# Altium schematic sheet PowerSupply.SchDoc(PowerSupply)
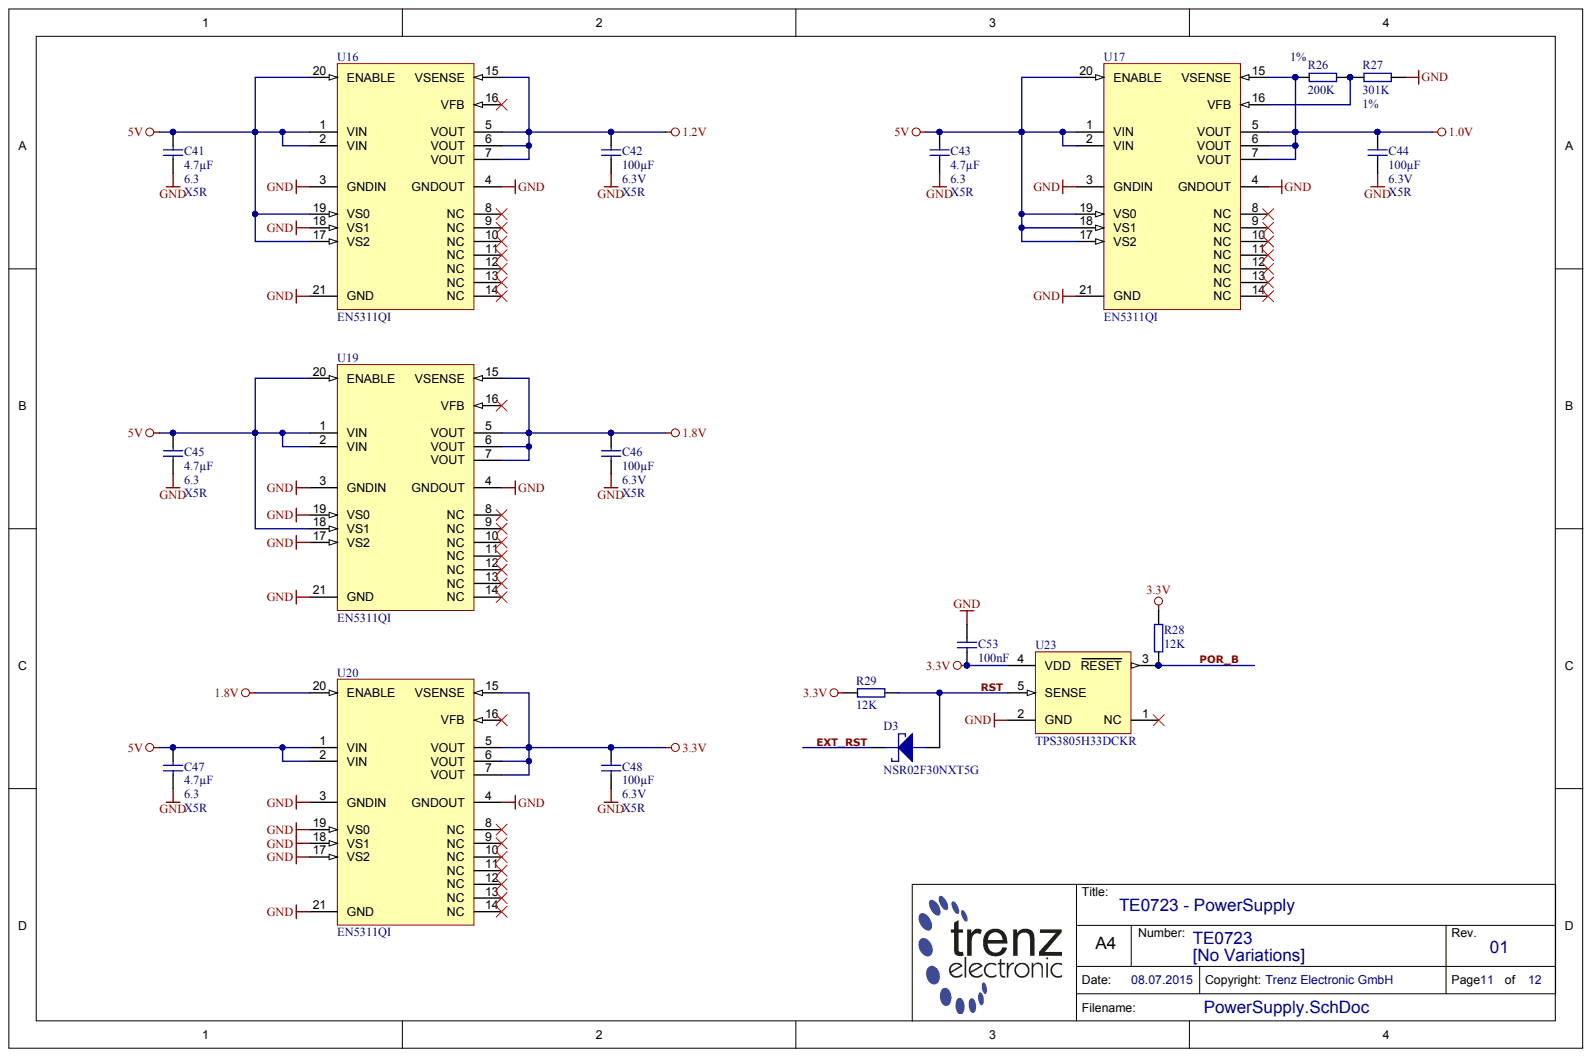
[diagram p0: PowerSupply.SchDoc]

## Parts
C41  4.7µF  Technology: X5R  Voltage: 6.3  [CAPC1005X60N]
C42  100µF  Technology: X5R  Voltage: 6.3V  [CAPC3216X180N]
C43  4.7µF  Technology: X5R  Voltage: 6.3  [CAPC1005X60N]
C44  100µF  Technology: X5R  Voltage: 6.3V  [CAPC3216X180N]
C45  4.7µF  Technology: X5R  Voltage: 6.3  [CAPC1005X60N]
C46  100µF  Technology: X5R  Voltage: 6.3V  [CAPC3216X180N]
C47  4.7µF  Technology: X5R  Voltage: 6.3  [CAPC1005X60N]
C48  100µF  Technology: X5R  Voltage: 6.3V  [CAPC3216X180N]
C53  100nF  [CAPC1005X55N]
D3  NSR02F30NXT5G  [DIOC0603X30N]
R26  200K  Tolerance: 1%  [RESC1005X40N]
R27  301K  Tolerance: 1%  [RESC1005X40N]
R28  12K  [RESC1005X40N]
R29  12K  [RESC1005X40N]
U16  EN5311QI  [EN5311QI]
U17  EN5311QI  [EN5311QI]
U19  EN5311QI  [EN5311QI]
U20  EN5311QI  [EN5311QI]
U23  TPS3805H33DCKR  [SOT65P210X110-5N]

## Nets
1.0V: C44.1 R26.1 U17.5(VOUT) U17.6(VOUT) U17.7(VOUT) U17.15(VSENSE)
1.2V: C42.1 U16.5(VOUT) U16.6(VOUT) U16.7(VOUT) U16.15(VSENSE)
1.8V: C46.1 U19.5(VOUT) U19.6(VOUT) U19.7(VOUT) U19.15(VSENSE) U20.20(ENABLE)
3.3V: C48.1 C53.2 R28.2 R29.2 U20.5(VOUT) U20.6(VOUT) U20.7(VOUT) U20.15(VSENSE) U23.4(VDD)
5V: C41.1 C43.1 C45.1 C47.1 U16.1(VIN) U16.2(VIN) U16.17(VS2) U16.19(VS0) U16.20(ENABLE) U17.1(VIN) U17.2(VIN) U17.17(VS2) U17.18(VS1) U17.19(VS0) U17.20(ENABLE) U19.1(VIN) U19.2(VIN) U19.18(VS1) U19.20(ENABLE) U20.1(VIN) U20.2(VIN)
GND: C41.2 C42.2 C43.2 C44.2 C45.2 C46.2 C47.2 C48.2 C53.1 R27.2 U16.3(GNDIN) U16.4(GNDOUT) U16.18(VS1) U16.21(GND) U17.3(GNDIN) U17.4(GNDOUT) U17.21(GND) U19.3(GNDIN) U19.4(GNDOUT) U19.17(VS2) U19.19(VS0) U19.21(GND) U20.3(GNDIN) U20.4(GNDOUT) U20.17(VS2) U20.18(VS1) U20.19(VS0) U20.21(GND) U23.2(GND)
EXT_RST: D3.1
POR_B: R28.1 U23.3(RESET)
RST: D3.2 R29.1 U23.5(SENSE)
NetR26_2: R26.2 R27.1 U17.16(VFB)

Not connected: U16 8(NC) 9(NC) 10(NC) 11(NC) 12(NC) 13(NC) 14(NC) 16(VFB); U17 8(NC) 9(NC) 10(NC) 11(NC) 12(NC) 13(NC) 14(NC); U19 8(NC) 9(NC) 10(NC) 11(NC) 12(NC) 13(NC) 14(NC) 16(VFB); U20 8(NC) 9(NC) 10(NC) 11(NC) 12(NC) 13(NC) 14(NC) 16(VFB); U23 1(NC)

## Library data of the parts
C41 C43 C45 C47 = 24719: KON 4.7µF 6.3V X5R SMD 20%; Database Table Name: C_1005_0402; Mixed: SMT; Preferred: Yes; Value: 4.7u; AN: 24719; Case: 1005 (0402); Component Kind: Standard; Library Name: TE.DbLib; Tolerance: 20%
C42 C44 C46 C48 = 23116: KON 100µF 6,3V X5R SMD 20%; Database Table Name: C_3216_1206; Mixed: SMT; Preferred: Yes; Value: 100u; AN: 23116; Case: 3216 (1206); Component Kind: Standard; Library Name: TE.DbLib; Tolerance: 20%
C53 = 26183: KON 100nF 50V SMD AEC-Q200; Database Table Name: A_C_1005_0402; Mixed: SMT; Tolerance: 10%; Value: 100n; AN: 26183; Case: 1005 (0402); Component Kind: Standard; Library Name: TE.DbLib; Technology: X7R; Voltage: 50V
D3 = 26175: Database Table Name: DIODE; Mixed: SMT; Trenz-Stock: Yes; AN: 26175; Case: DSN2 - 0201; Component Kind: Standard; Description: NSR02F30NXT5G; Library Name: TE.DbLib; HelpURL: B:\ad\adlib\datasheet\NSR02F30NXT5G
R26 = 23846: WID SMD 200K 1% 0,063W; Database Table Name: R_1005_0402; Mixed: SMT; Preferred: Yes; AN: 23846; Case: 1005 (0402); Component Kind: Standard; Library Name: TE.DbLib; Value: 200K
R27 = 26224: WID SMD 301K 1% 0,063W; Database Table Name: R_1005_0402; Mixed: SMT; AN: 26224; Case: 1005 (0402); Component Kind: Standard; Library Name: TE.DbLib; Value: 301k
R28 R29 = 22598: WID SMD 12K 1% 0,063W; Database Table Name: R_1005_0402; Mixed: SMT; Preferred: Yes; AN: 22598; Case: 1005 (0402); Component Kind: Standard; Library Name: TE.DbLib; Value: 12K
U16 U17 U19 U20 = 25492: Database Table Name: DCDC; Mixed: SMT; Preferred: Yes; AN: 25492; Case: QFN20; Component Kind: Standard; Description: EN5311QI; Library Name: TE.DbLib; Trenz-Stock: Yes; HelpURL: B:\AD\adlib\datasheet\EN5311QI
U23 = 25049: Database Table Name: SUPERVISOR; Mixed: SMT; Preferred: Yes; AN: 25049; Case: SC70-5 / SC88A / SOT353; Component Kind: Standard; Description: TPS3805H33DCKR; Library Name: TE.DbLib; Trenz-Stock: Yes; HelpURL: B:\AD\adlib\datasheet\TPS3805H33
